# Altium schematic sheet lm2596s schematic_2022-03-02.schdoc
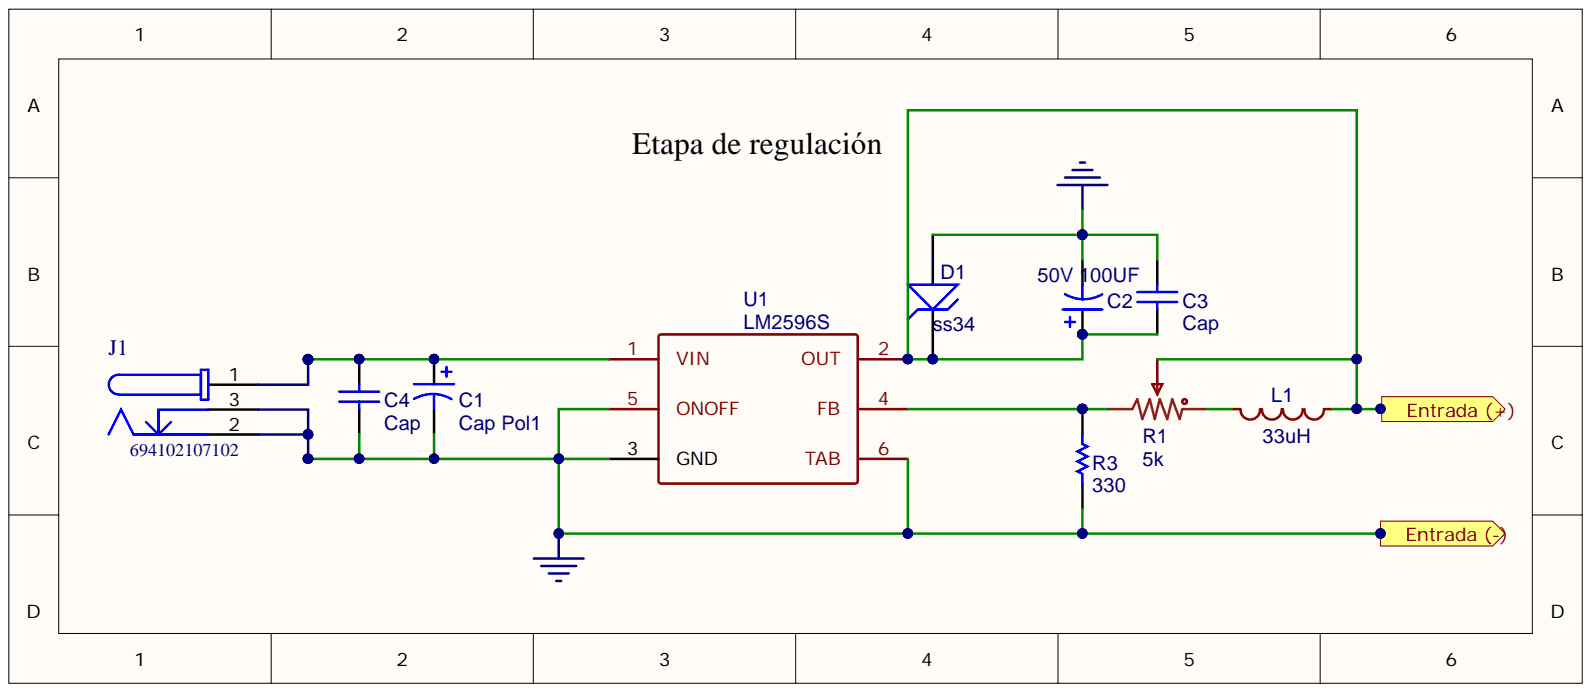
[diagram p0: lm2596s schematic_2022-03-02.schdoc]

## Parts
C1  Cap Pol1  [CAP8*12]
C2  50V 100UF  [CAP8*12]
C3  Cap  [C0805]
C4  Cap  [C0805]
D1  ss34  [DIODES_PICK]
J1  694102107102  [694102107102]
L1  33uH  [CD127 12*12*7MM]
R1  5k  [RW]
R3  330  [C0805]
U1  LM2596S  [TS5B]

## Ports
Entrada (+), Entrada (-)

## Nets
GND: C1.2 C2.2 C3.2 C4.1 D1.1 J1.2 J1.3 R3.1 U1.3(GND) U1.5(ONOFF) U1.6(TAB)
NetC1_1: C1.1 C4.2 J1.1 U1.1(VIN)
NetC2_1: C2.1 C3.1 D1.2 L1.P$1 R1.2 U1.2(OUT)
NetL1_P$2: L1.P$2 R1.3
NetR1_1: R1.1 R3.2 U1.4(FB)

## Library data of the parts
C1 = Cap Pol1: catid: 20; sourceId: d1VdvpHhC; BOM_Manufacturer Part: ?; Component Kind: Standard; spicePre: C; timeStamp: 1452930407
C2 = 50V 100UF: catid: 20; sourceId: d1VdvpHhC; BOM_Manufacturer Part: ?; Component Kind: Standard; spicePre: C; timeStamp: 1452930407
C3 C4 = Cap: catid: 20; sourceId: aYgasJ1jB; BOM_Manufacturer Part: ?; Component Kind: Standard; spicePre: C; timeStamp: 1452930407
D1 = ss34: catid: 20; sourceId: RpmkigCAV; BOM_Manufacturer Part: ?; Component Kind: Standard; spicePre: D; timeStamp: 1453706919
J1 = CMP-1502-00174-1: DC Power Jack Connector Low Profile with 4 soldering pads & Pegs WR-DC, Male, Right Angled, SMT, 5 A, 18 V; Manufacturer: Wurth Elektronik; Opening Diameter: 3.9mm; Plug Inner Diameter: 1.05mm; Plug Outer Diameter: 3.5mm; Rated Current: 5A; Working Voltage: 18V; Component Kind: Standard; Datasheet URL: http://katalog.we-online.de/em/datasheet/6941xx107102.pdf; Gender: Male; Library Name: Altium Content Vault; Manufacturer URL: http://www.we-online.de; Mounting Technology: SMT; Operating Temperature: -40to85degC; Orientation: RightAngled; Package Reference: 694102107102; Packaging: TapeandReel; Part Number: 694102107102; Publisher: WurthElektronik
L1 = 33uH: nameAlias: Value(H); BOM_Manufacturer Part: ?; Component Kind: Standard; spicePre: L; spiceSymbolName: Inductor
R1 = 5k: K(%): 50; Model: Potentiometer; nameAlias: Value(Ω); BOM_Manufacturer Part: ?; Component Kind: Standard; spicePre: X; spiceSymbolName: Potentiometer
R3 = 330: catid: 20; sourceId: RoYWUgdzx; BOM_Manufacturer Part: ?; Component Kind: Standard; spicePre: R; timeStamp: 1453706919
U1 = LM2596S: catid: 20; sourceId: NUuTiVRn0; BOM_Manufacturer Part: ?; Component Kind: Standard; spicePre: U; timeStamp: 1457681323
